# Altium schematic sheet power.SchDoc(power)
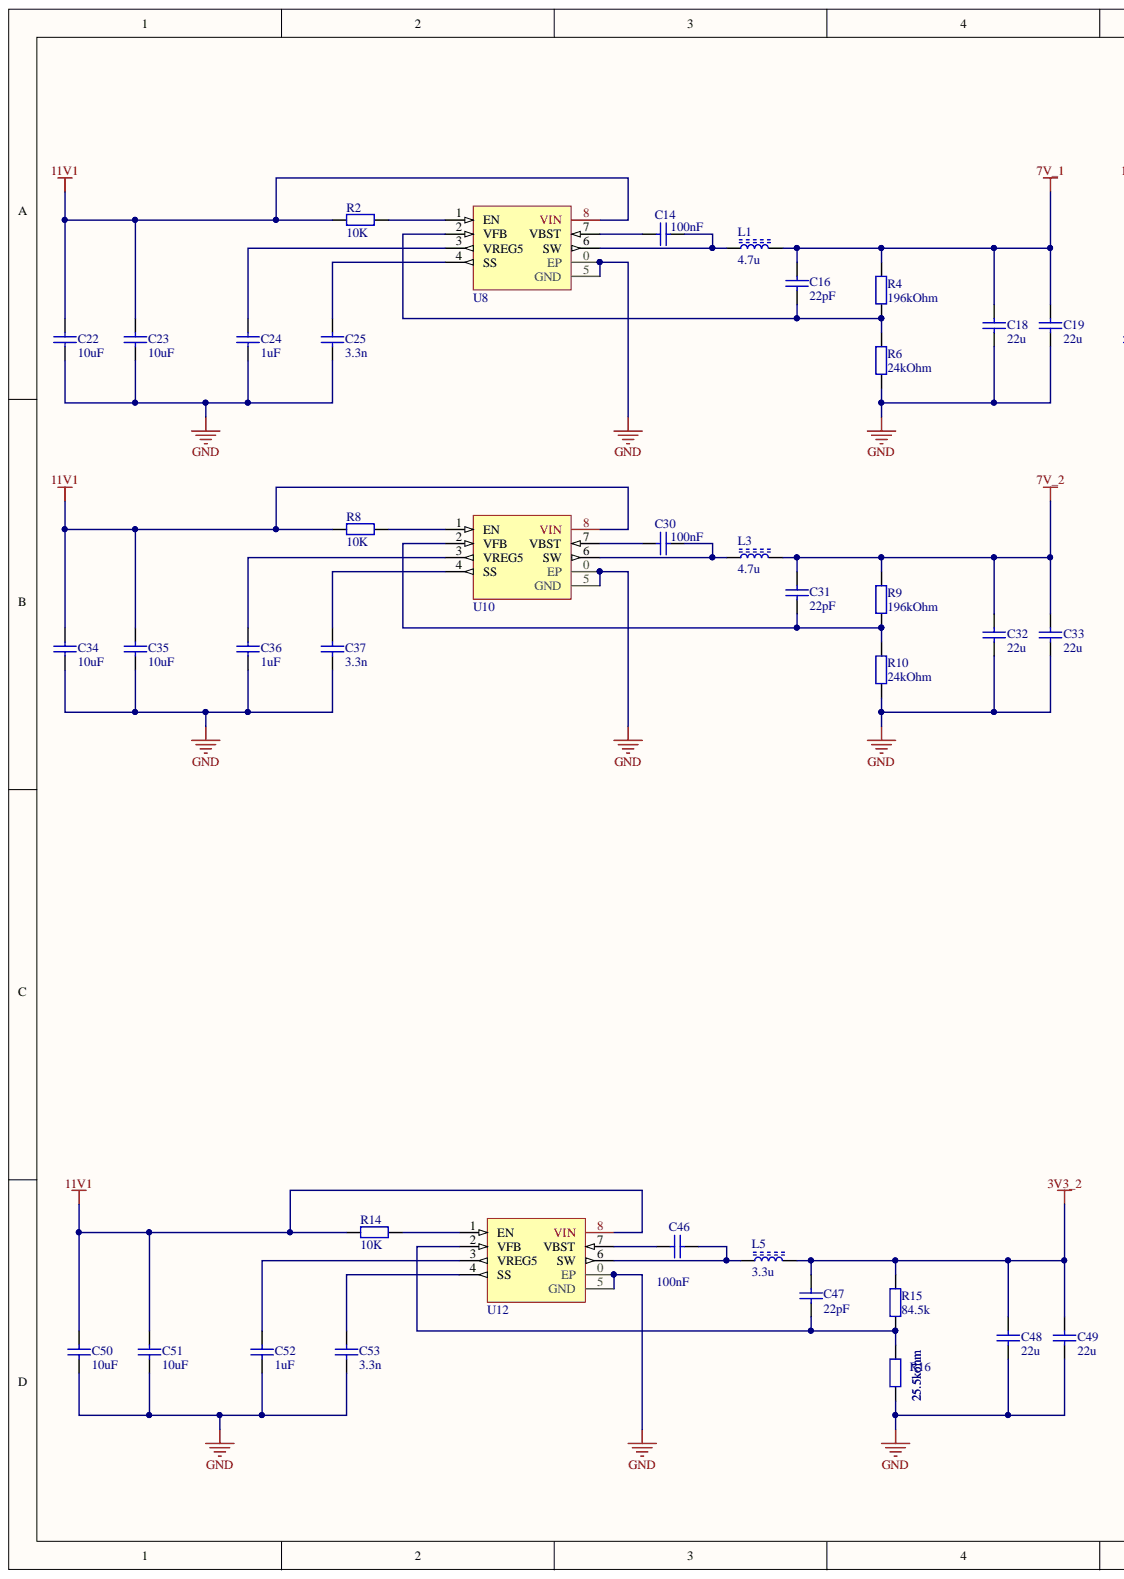
[diagram p0: power.SchDoc, left]
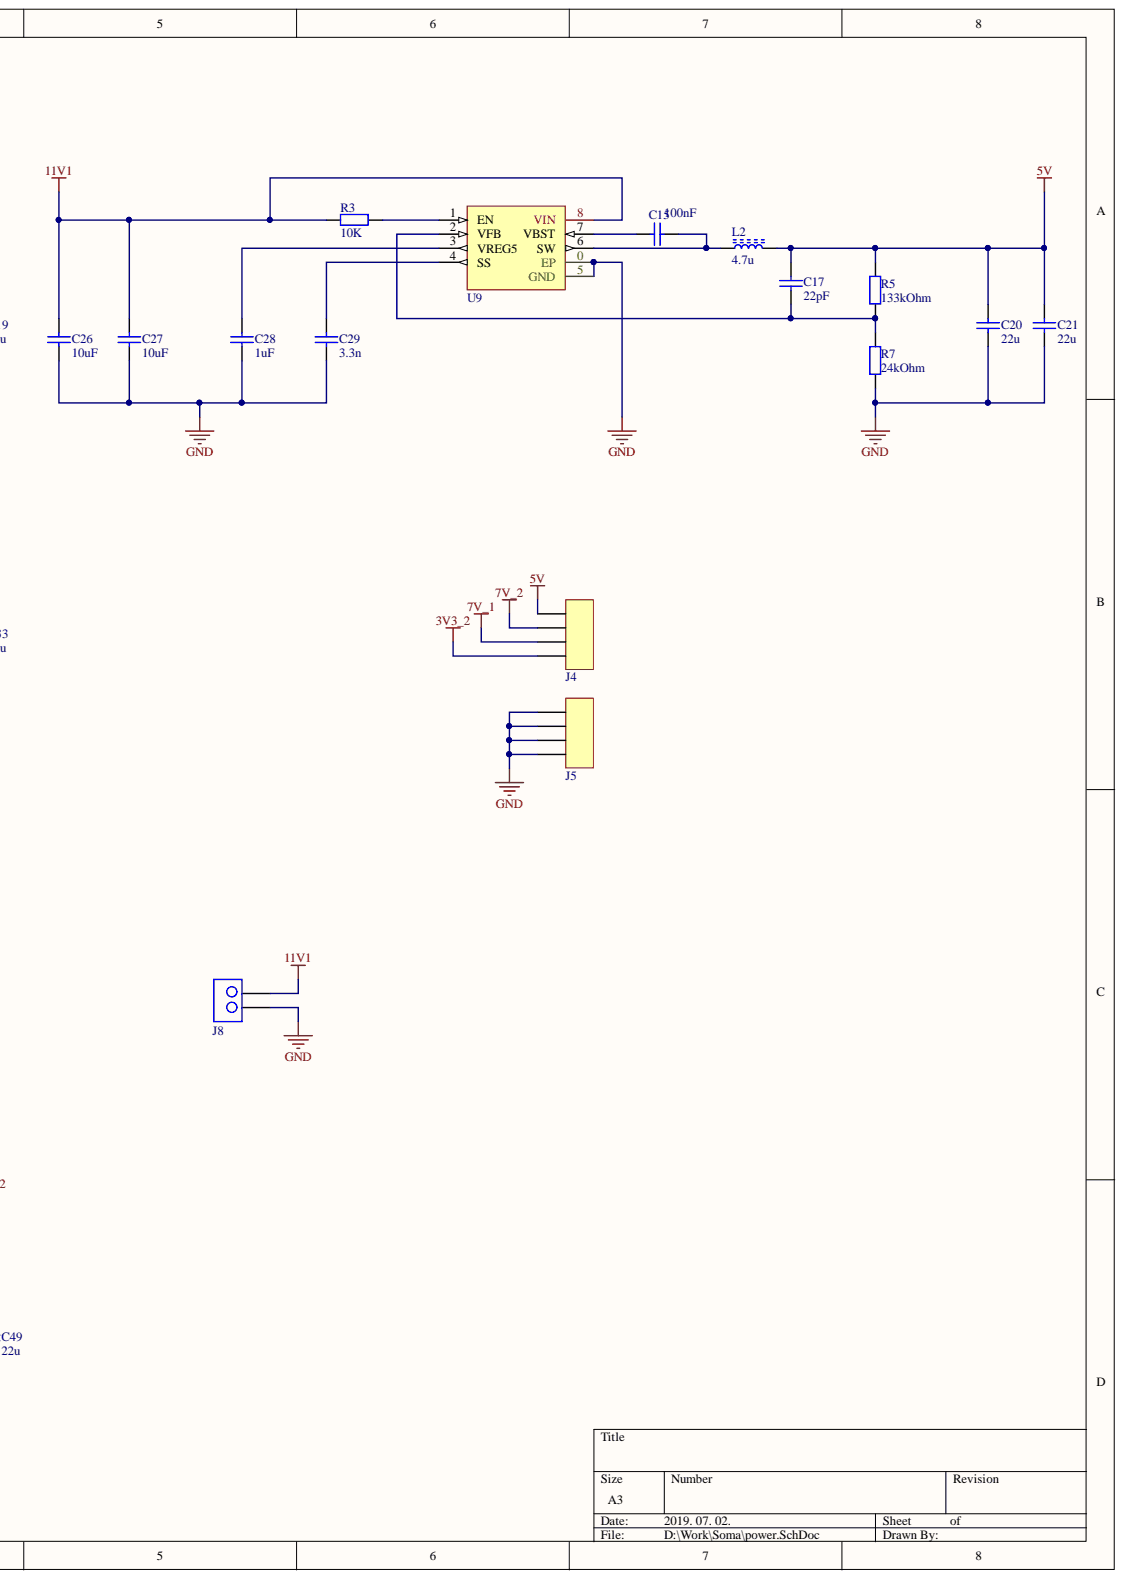
[diagram p1: power.SchDoc, right]

## Parts
C14  100nF  [FP_0805_CAP_085]
C15  100nF  [FP_0805_CAP_085]
C16  22pF  [FP_0805_CAP_085]
C17  22pF  [FP_0805_CAP_085]
C18  Capacitance: 22u  [1210]  (hidden comment: CL32A226KOJNNNE)
C19  Capacitance: 22u  [1210]  (hidden comment: CL32A226KOJNNNE)
C20  Capacitance: 22u  [1210]  (hidden comment: CL32A226KOJNNNE)
C21  Capacitance: 22u  [1210]  (hidden comment: CL32A226KOJNNNE)
C22  Capacitance: 10uF  [1210]  (hidden comment: 885012209005)
C23  Capacitance: 10uF  [1210]  (hidden comment: 885012209005)
C24  Capacitance: 1uF  [0805]  (hidden comment: VJ0805Y105KXQTW1BC)
C25  Capacitance: 3.3n  [0805]  (hidden comment: CC0805JRNP08BN332)
C26  Capacitance: 10uF  [1210]  (hidden comment: 885012209005)
C27  Capacitance: 10uF  [1210]  (hidden comment: 885012209005)
C28  Capacitance: 1uF  [0805]  (hidden comment: VJ0805Y105KXQTW1BC)
C29  Capacitance: 3.3n  [0805]  (hidden comment: CC0805JRNP08BN332)
C30  100nF  [FP_0805_CAP_085]
C31  22pF  [FP_0805_CAP_085]
C32  Capacitance: 22u  [1210]  (hidden comment: CL32A226KOJNNNE)
C33  Capacitance: 22u  [1210]  (hidden comment: CL32A226KOJNNNE)
C34  Capacitance: 10uF  [1210]  (hidden comment: 885012209005)
C35  Capacitance: 10uF  [1210]  (hidden comment: 885012209005)
C36  Capacitance: 1uF  [0805]  (hidden comment: VJ0805Y105KXQTW1BC)
C37  Capacitance: 3.3n  [0805]  (hidden comment: CC0805JRNP08BN332)
C46  100nF  [FP_0805_CAP_085]
C47  22pF  [FP_0805_CAP_085]
C48  Capacitance: 22u  [1210]  (hidden comment: CL32A226KOJNNNE)
C49  Capacitance: 22u  [1210]  (hidden comment: CL32A226KOJNNNE)
C50  Capacitance: 10uF  [1210]  (hidden comment: 885012209005)
C51  Capacitance: 10uF  [1210]  (hidden comment: 885012209005)
C52  Capacitance: 1uF  [0805]  (hidden comment: VJ0805Y105KXQTW1BC)
C53  Capacitance: 3.3n  [0805]  (hidden comment: CC0805JRNP08BN332)
J4  4-Pin Header  [4-Pin Header]  (value not drawn)
J5  4-Pin Header  [4-Pin Header]  (value not drawn)
J8  1935776  [PT 2,5/ 2-5,0-H]  (value not drawn)
L1  Inductance: 4.7u  [TDK_IND_6045]  (hidden comment: VLS6045EX-4R7M)
L2  Inductance: 4.7u  [TDK_IND_6045]  (hidden comment: VLS6045EX-4R7M)
L3  Inductance: 4.7u  [TDK_IND_6045]  (hidden comment: VLS6045EX-4R7M)
L5  Inductance: 3.3u  [TDK_IND_5045]  (hidden comment: VLS5045EX-3R3N)
R2  10K  [FP_0805_RES]
R3  10K  [FP_0805_RES]
R4  Resistance: 196kOhm  [0805-W]  (hidden comment: CR0805-FX-1963ELF)
R5  Resistance: 133kOhm  [0805-W]  (hidden comment: CR0805-FX-1333ELF)
R6  Resistance: 24kOhm  [0805-W]  (hidden comment: CR0805-FX-2402ELF)
R7  Resistance: 24kOhm  [0805-W]  (hidden comment: CR0805-FX-2402ELF)
R8  10K  [FP_0805_RES]
R9  Resistance: 196kOhm  [0805-W]  (hidden comment: CR0805-FX-1963ELF)
R10  Resistance: 24kOhm  [0805-W]  (hidden comment: CR0805-FX-2402ELF)
R14  10K  [FP_0805_RES]
R15  Resistance: 84.5k  [0805-W]  (hidden comment: CRCW080584K5FKEA)
R16  MCMR08X2552FTL  [0805-W]  (value not drawn)
U8  TPS54227DDAR  [8-Pin HSOP with ThermalPad]  (value not drawn)
U9  TPS54227DDAR  [8-Pin HSOP with ThermalPad]  (value not drawn)
U10  TPS54227DDAR  [8-Pin HSOP with ThermalPad]  (value not drawn)
U12  TPS54227DDAR  [8-Pin HSOP with ThermalPad]  (value not drawn)

## Nets
3V3_2: C47.2 C48.2 C49.2 J4.4 L5.2 R15.2
5V: C17.2 C20.2 C21.2 J4.1 L2.2 R5.2
7V_1: C16.2 C18.2 C19.2 J4.3 L1.2 R4.2
7V_2: C31.2 C32.2 C33.2 J4.2 L3.2 R9.2
11V1: C22.2 C23.2 C26.2 C27.2 C34.2 C35.2 C50.2 C51.2 J8.2 R2.1 R3.1 R8.1 R14.1 U8.8(VIN) U9.8(VIN) U10.8(VIN) U12.8(VIN)
GND: C18.1 C19.1 C20.1 C21.1 C22.1 C23.1 C24.1 C25.1 C26.1 C27.1 C28.1 C29.1 C32.1 C33.1 C34.1 C35.1 C36.1 C37.1 C48.1 C49.1 C50.1 C51.1 C52.1 C53.1 J5.1 J5.2 J5.3 J5.4 J8.1 R6.1 R7.1 R10.1 R16.1 U8.0(EP) U8.5(GND) U9.0(EP) U9.5(GND) U10.0(EP) U10.5(GND) U12.0(EP) U12.5(GND)
NetC14_1: C14.1 U8.7(VBST)
NetC14_2: C14.2 L1.1 U8.6(SW)
NetC15_1: C15.1 U9.7(VBST)
NetC15_2: C15.2 L2.1 U9.6(SW)
NetC16_1: C16.1 R4.1 R6.2 U8.2(VFB)
NetC17_1: C17.1 R5.1 R7.2 U9.2(VFB)
NetC24_2: C24.2 U8.3(VREG5)
NetC25_2: C25.2 U8.4(SS)
NetC28_2: C28.2 U9.3(VREG5)
NetC29_2: C29.2 U9.4(SS)
NetC30_1: C30.1 U10.7(VBST)
NetC30_2: C30.2 L3.1 U10.6(SW)
NetC31_1: C31.1 R9.1 R10.2 U10.2(VFB)
NetC36_2: C36.2 U10.3(VREG5)
NetC37_2: C37.2 U10.4(SS)
NetC46_1: C46.1 U12.7(VBST)
NetC46_2: C46.2 L5.1 U12.6(SW)
NetC47_1: C47.1 R15.1 R16.2 U12.2(VFB)
NetC52_2: C52.2 U12.3(VREG5)
NetC53_2: C53.2 U12.4(SS)
NetR2_2: R2.2 U8.1(EN)
NetR3_2: R3.2 U9.1(EN)
NetR8_2: R8.2 U10.1(EN)
NetR14_2: R14.2 U12.1(EN)

## Library data of the parts
C14 C15 C30 C46 = CAP 100nF: Capacitor; Voltage: 25V; Tolerance: +80%/-20%; Reference: 1759167; Name: SMD Multilayer Ceramic Capacitor, 0805; Value: 100nF; Supplier: Farnell; Component Kind: Standard; Library Name: hallglib.SchLib
C16 C17 C31 C47 = CAP 22pF: Capacitor; Voltage: 50V; Tolerance: 5%; Reference: 1759195; Name: SMD Multilayer Ceramic Capacitor, 0805; Value: 22pF; Supplier: Farnell; Component Kind: Standard; Library Name: hallglib.SchLib
C18-C21 C32 C33 C48 C49 = CL32A226KOJNNNE: 22uF 1210 10% 16V X5R CL32A226KOJNNNE (SAM) [ 82-08-05 ]; Component Kind: Standard; Library Name: RobonAUT.SchLib
C22 C23 C26 C27 C34 C35 C50 C51 = 885012209005: SMD Multilayer Ceramic Capacitor, 1210 [3225 Metric], 10 µF, 10 V, ± 10%, X7R, WCAP-CSGP Series; Component Kind: Standard; Library Name: RobonAUT.SchLib
C24 C28 C36 C52 = VJ0805Y105KXQTW1BC: SMD Multilayer Ceramic Capacitor, 0805 [2012 Metric], 1 µF, 10 V, ± 10%, X7R, VJ Series; Component Kind: Standard; Library Name: RobonAUT.SchLib
C25 C29 C37 C53 = CC0805JRNP08BN332: 3.3nF 0805 5% 25V NP0 CC0805JRNP08BN332 (YAG) [ 82-04-69 ]; Component Kind: Standard; Library Name: RobonAUT.SchLib
J8 = 1935776: PCB terminal block, nominal current: 32 A, nom. voltage: 400 V, pitch: 5 mm, number of positions: 2; Library Name: HomeProjects.SchLib; Component Kind: Standard
L1-L3 = VLS6045EX-4R7M: Power Inductor (SMD), 4.7 µH, 4.2 A, Wirewound, 5.8 A, VLS-EX Series, 6mm x 6mm x 4.5mm; Component Kind: Standard; Library Name: RobonAUT.SchLib
L5 = VLS5045EX-3R3N: Power Inductor (SMD), 3.3 µH, 4.2 A, Wirewound, 5.2 A, VLS-EX series, 5mm x 5mm x 4.5mm; Component Kind: Standard; Library Name: RobonAUT.SchLib
R2 R3 R8 R14 = RES 10K: Resistor; Tolerance: 5%; Reference: 9234136; Power: 125mW; Name: YAGEO (PHYCOMP)  RC0805JR-0710KL  SMD Chip Resistor, Thick Film, 10 kohm; Value: 10K; Supplier: Farnell; Component Kind: Standard; Library Name: hallglib.SchLib
R4 R9 = CR0805-FX-1963ELF: SMD Chip Resistor, 196kOhm, 0805; Library Name: HomeProjects.SchLib; Component Kind: Standard
R5 = CR0805-FX-1333ELF: SMD Chip Resistor, 133kOhm, 0805; Library Name: HomeProjects.SchLib; Component Kind: Standard
R6 R7 R10 = CR0805-FX-2402ELF: SMD Chip Resistor, 24kOhm, 0805; Library Name: HomeProjects.SchLib; Component Kind: Standard
R15 = CRCW080584K5FKEA: SMD Chip Resistor, 84.5 kohm, CRCW Series, 150 V, Thick Film, 0805 [2012 Metric], 125 mW; Component Kind: Standard; Library Name: RobonAUT.SchLib
R16 = MCMR08X2552FTL: SMD Chip Resistor, Ceramic, 25.5 kohm, MCMR Series, 150 V, Thick Film, 0805 [2012 Metric], 125 mW; Component Kind: Standard; Library Name: RobonAUT.SchLib
U8-U10 U12 = TPS54227DDAR: DC-DC Switching (Step Down) Regulator, Adjustable, 700 kHz, 4.5-18Vin, up to 7V/2Aout, SO PowerPAD-8; Component Kind: Standard; Library Name: RobonAUT.SchLib
J4 J5 = 4-Pin Header: Component Kind: Standard; Library Name: RobonAUT.SchLib
